# Altium schematic sheet Sens_Prob.SchDoc
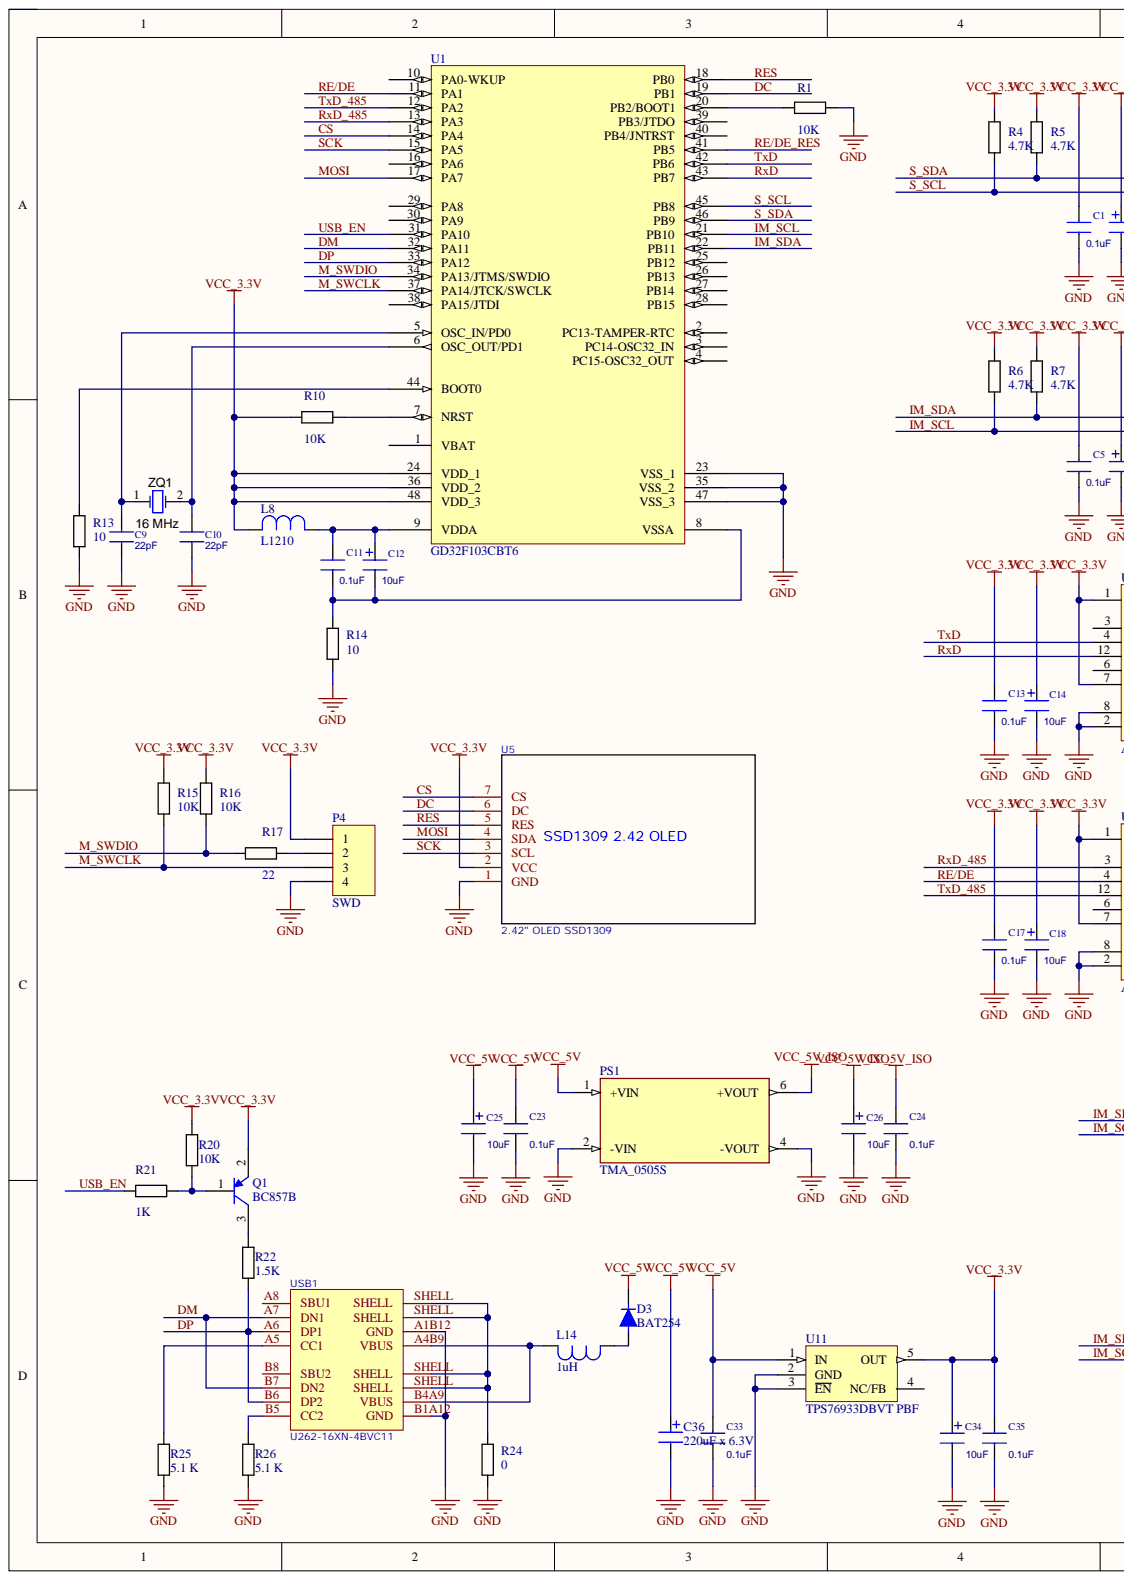
[diagram p0: Sens_Prob.SchDoc, left]
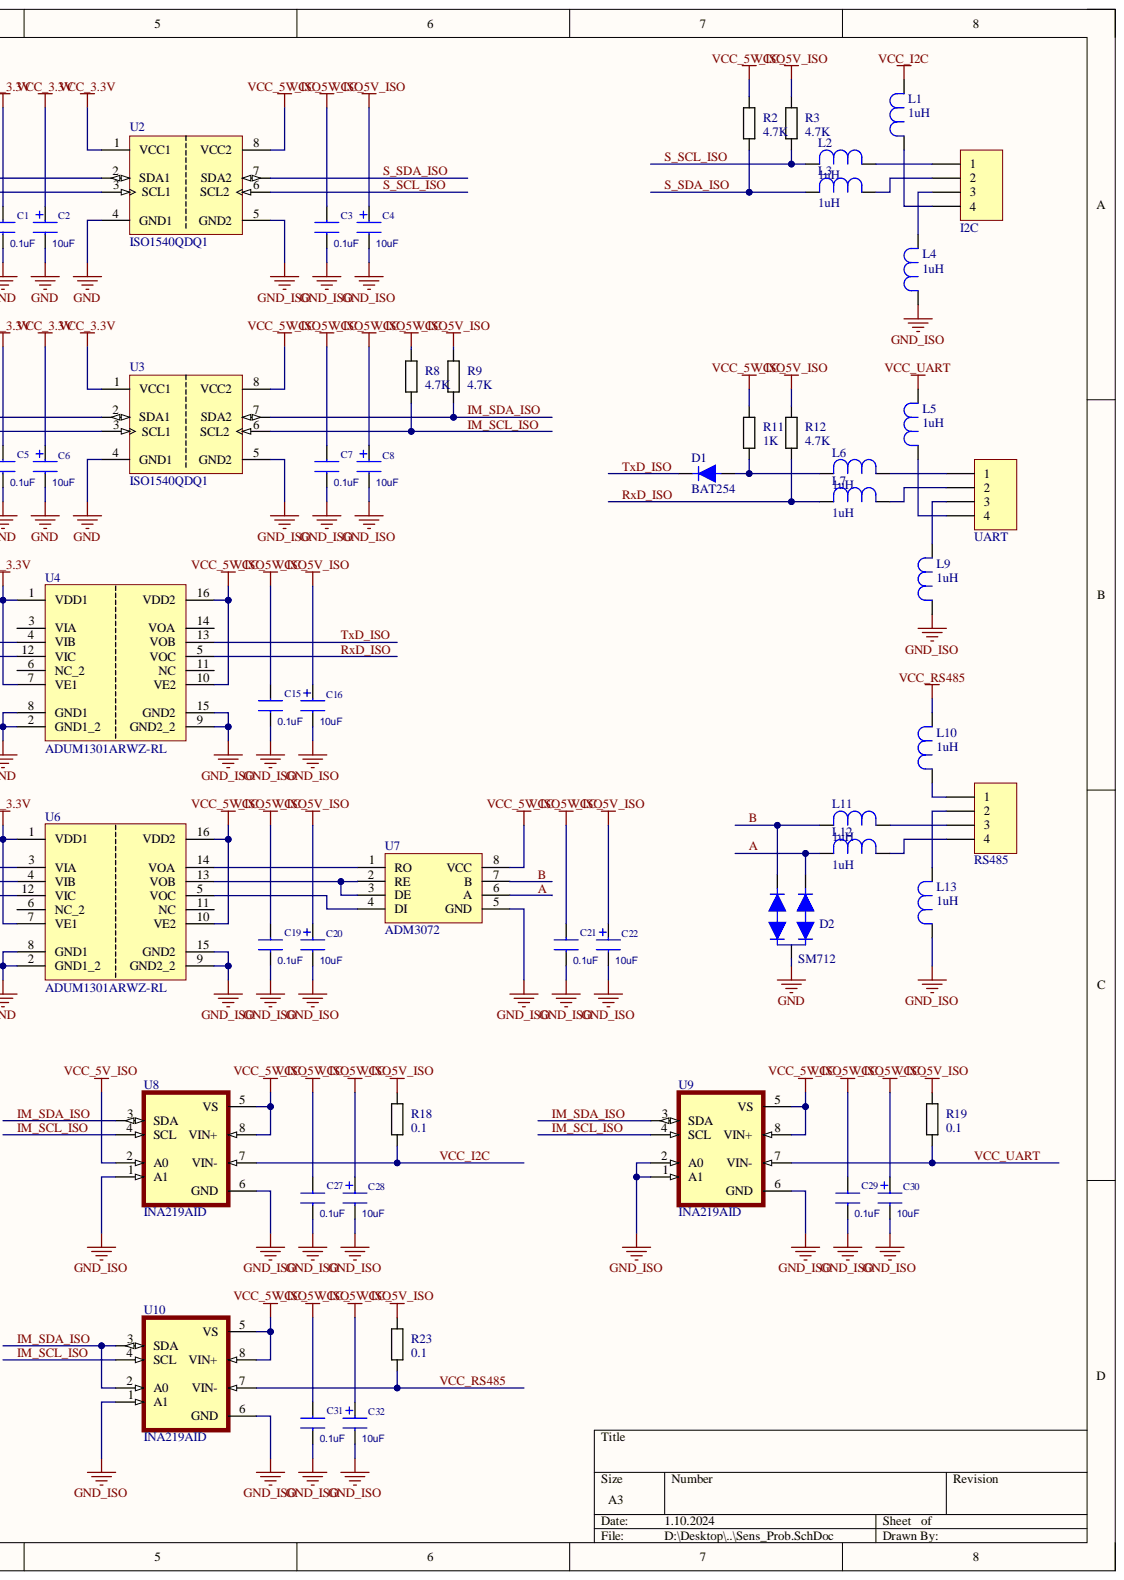
[diagram p1: Sens_Prob.SchDoc, right]

## Parts
C1  0.1uF  [C_0805]
C2  10uF  [CPM3528]
C3  0.1uF  [C_0805]
C4  10uF  [CPM3528]
C5  0.1uF  [C_0805]
C6  10uF  [CPM3528]
C7  0.1uF  [C_0805]
C8  10uF  [CPM3528]
C9  22pF  [C_0805]
C10  22pF  [C_0805]
C11  0.1uF  [C_0805]
C12  10uF  [CPM3528]
C13  0.1uF  [C_0805]
C14  10uF  [CPM3528]
C15  0.1uF  [C_0805]
C16  10uF  [CPM3528]
C17  0.1uF  [C_0805]
C18  10uF  [CPM3528]
C19  0.1uF  [C_0805]
C20  10uF  [CPM3528]
C21  0.1uF  [C_0805]
C22  10uF  [CPM3528]
C23  0.1uF  [C_0805]
C24  0.1uF  [C_0805]
C25  10uF  [CPM3528]
C26  10uF  [CPM3528]
C27  0.1uF  [C_0805]
C28  10uF  [CPM3528]
C29  0.1uF  [C_0805]
C30  10uF  [CPM3528]
C31  0.1uF  [C_0805]
C32  10uF  [CPM3528]
C33  0.1uF  [C_0805]
C34  10uF  [CPM3528]
C35  0.1uF  [C_0805]
C36  220uF x 6.3V  [Radial 8x11.5]
D1  BAT254  [SOD-110]
D2  SM712  [SOT23]
D3  BAT254  [SOD-110]
L1  MLZ2012M100WT000  [L_0805]  (value not drawn)
L2  MLZ2012M100WT000  [L_0805]  (value not drawn)
L3  MLZ2012M100WT000  [L_0805]  (value not drawn)
L4  MLZ2012M100WT000  [L_0805]  (value not drawn)
L5  MLZ2012M100WT000  [L_0805]  (value not drawn)
L6  MLZ2012M100WT000  [L_0805]  (value not drawn)
L7  MLZ2012M100WT000  [L_0805]  (value not drawn)
L8  L1210  [L_1210]
L9  MLZ2012M100WT000  [L_0805]  (value not drawn)
L10  MLZ2012M100WT000  [L_0805]  (value not drawn)
L11  MLZ2012M100WT000  [L_0805]  (value not drawn)
L12  MLZ2012M100WT000  [L_0805]  (value not drawn)
L13  MLZ2012M100WT000  [L_0805]  (value not drawn)
L14  MLZ2012M100WT000  [L_0805]  (value not drawn)
P1  I2C  [MHDR1X4]
P2  UART  [MHDR1X4]
P3  RS485  [MHDR1X4]
P4  SWD  [HDR1X4]
PS1  TMA_0505S  [CONV_TMA_0505S]
Q1  BC857B  [SOT-23A_M]
R1  10K  [Resistor 0805]
R2  4.7K  [Resistor 0805]
R3  4.7K  [Resistor 0805]
R4  4.7K  [Resistor 0805]
R5  4.7K  [Resistor 0805]
R6  4.7K  [Resistor 0805]
R7  4.7K  [Resistor 0805]
R8  4.7K  [Resistor 0805]
R9  4.7K  [Resistor 0805]
R10  10K  [Resistor 0805]
R11  1K  [Resistor 0805]
R12  4.7K  [Resistor 0805]
R13  10  [Resistor 0805]
R14  10  [Resistor 0805]
R15  10K  [Resistor 0805]
R16  10K  [Resistor 0805]
R17  22  [Resistor 0805]
R18  0.1  [RESC6331X70N]
R19  0.1  [RESC6331X70N]
R20  10K  [Resistor 0805]
R21  1K  [Resistor 0805]
R22  1.5K  [Resistor 0805]
R23  0.1  [RESC6331X70N]
R24  0  [Resistor 0805]
R25  5.1 K  [Resistor 0805]
R26  5.1 K  [Resistor 0805]
U1  GD32F103CBT6  [LQFP48_L]
U2  ISO1540QDQ1  [SO-8]
U3  ISO1540QDQ1  [SO-8]
U4  ADUM1301ARWZ-RL  [SOIC16W]
U5  2.42" OLED SSD1309  [2.42" OLED]
U6  ADUM1301ARWZ-RL  [SOIC16W]
U7  ADM3072  [SO-8]
U8  INA219AID  [SO-8]
U9  INA219AID  [SO-8]
U10  INA219AID  [SO-8]
U11  TPS76933DBVT PBF  [sot23-5]
USB1  U262-16XN-4BVC11  [USB-3.1-SMD_U262-161N-4BVC11]
ZQ1  16 MHz  [HC49S]

## Nets
GND: C1.1 C2.2 C5.1 C6.2 C9.1 C10.1 C13.1 C14.2 C17.1 C18.2 C23.1 C24.1 C25.2 C26.2 C33.1 C34.2 C35.1 C36.2 D2.3 P4.4 PS1.2(-VIN) PS1.4(-VOUT) R1.2 R13.1 R14.1 R24.1 R25.1 R26.1 U1.23(VSS_1) U1.35(VSS_2) U1.47(VSS_3) U2.4(GND1) U3.4(GND1) U4.2(GND1_2) U4.8(GND1) U5.1(GND) U6.2(GND1_2) U6.8(GND1) U11.2(GND) U11.3(EN) USB1.A1B12(GND) USB1.B1A12(GND)
GND_ISO: C3.1 C4.2 C7.1 C8.2 C15.1 C16.2 C19.1 C20.2 C21.1 C22.2 C27.1 C28.2 C29.1 C30.2 C31.1 C32.2 L4.2 L9.2 L13.2 U2.5(GND2) U3.5(GND2) U4.9(GND2_2) U4.15(GND2) U6.9(GND2_2) U6.15(GND2) U7.5(GND) U8.1(A1) U8.6(GND) U9.1(A1) U9.2(A0) U9.6(GND) U10.1(A1) U10.6(GND)
VCC_3.3V: C1.2 C2.1 C5.2 C6.1 C13.2 C14.1 C17.2 C18.1 C34.1 C35.2 L8.1 P4.1 Q1.2 R4.2 R5.2 R6.2 R7.2 R10.2 R15.2 R16.2 R20.2 U1.24(VDD_1) U1.36(VDD_2) U1.48(VDD_3) U2.1(VCC1) U3.1(VCC1) U4.1(VDD1) U4.7(VE1) U5.2(VCC) U6.1(VDD1) U6.7(VE1) U11.5(OUT)
VCC_5V: C23.2 C25.1 C33.2 C36.1 D3.2 PS1.1(+VIN) U11.1(IN)
VCC_5V_ISO: C3.2 C4.1 C7.2 C8.1 C15.2 C16.1 C19.2 C20.1 C21.2 C22.1 C24.2 C26.1 C27.2 C28.1 C29.2 C30.1 C31.2 C32.1 PS1.6(+VOUT) R2.2 R3.2 R8.2 R9.2 R11.2 R12.2 R18.2 R19.2 R23.2 U2.8(VCC2) U3.8(VCC2) U4.10(VE2) U4.16(VDD2) U6.10(VE2) U6.16(VDD2) U7.8(VCC) U8.2(A0) U8.5(VS) U8.8(VIN+) U9.5(VS) U9.8(VIN+) U10.5(VS) U10.8(VIN+)
A: D2.1 L12.2
B: D2.2 L11.2 U7.7(B)
CS: U1.14(PA4) U5.7(CS)
DC: U1.19(PB1) U5.6(DC)
DM: U1.32(PA11) USB1.A7(DN1) USB1.B7(DN2)
DP: R22.1 U1.33(PA12) USB1.A6(DP1) USB1.B6(DP2)
IM_SCL: R6.1 U1.21(PB10) U3.3(SCL1)
IM_SCL_ISO: R8.1 U3.6(SCL2) U8.4(SCL) U9.4(SCL) U10.4(SCL)
IM_SDA: R7.1 U1.22(PB11) U3.2(SDA1)
IM_SDA_ISO: R9.1 U3.7(SDA2) U8.3(SDA) U9.3(SDA) U10.2(A0) U10.3(SDA)
M_SWCLK: P4.3 R15.1 U1.37(PA14/JTCK/SWCLK)
M_SWDIO: R16.1 R17.1 U1.34(PA13/JTMS/SWDIO)
MOSI: U1.17(PA7) U5.4(SDA)
RE/DE: U1.11(PA1) U6.4(VIB)
RE/DE_RES: U1.41(PB5)
RES: U1.18(PB0) U5.5(RES)
RxD: U1.43(PB7) U4.12(VIC)
RxD_485: U1.13(PA3) U6.3(VIA)
RxD_ISO: L7.2 R12.1 U4.5(VOC)
S_SCL: R4.1 U1.45(PB8) U2.3(SCL1)
S_SCL_ISO: L2.2 R3.1 U2.6(SCL2)
S_SDA: R5.1 U1.46(PB9) U2.2(SDA1)
S_SDA_ISO: L3.2 R2.1 U2.7(SDA2)
SCK: U1.15(PA5) U5.3(SCL)
TxD: U1.42(PB6) U4.4(VIB)
TxD_485: U1.12(PA2) U6.12(VIC)
TxD_ISO: D1.2 U4.13(VOB)
USB_EN: R21.2 U1.31(PA10)
VCC_I2C: L1.1 R18.1 U8.7(VIN-)
VCC_RS485: L10.1 R23.1 U10.7(VIN-)
VCC_UART: L5.1 R19.1 U9.7(VIN-)
NetC9_2: C9.2 U1.5(OSC_IN/PD0) ZQ1.1
NetC10_2: C10.2 U1.6(OSC_OUT/PD1) ZQ1.2
NetC11_1: C11.1 C12.2 R14.2 U1.8(VSSA)
NetC11_2: C11.2 C12.1 L8.2 U1.9(VDDA)
NetD1_1: D1.1 L6.2 R11.1
NetD3_1: D3.1 L14.2
NetL1_2: L1.2 P1.4
NetL2_1: L2.1 P1.1
NetL3_1: L3.1 P1.2
NetL4_1: L4.1 P1.3
NetL5_2: L5.2 P2.4
NetL6_1: L6.1 P2.1
NetL7_1: L7.1 P2.2
NetL9_1: L9.1 P2.3
NetL10_2: L10.2 P3.1
NetL11_1: L11.1 P3.3
NetL12_1: L12.1 P3.4
NetL13_1: L13.1 P3.2
NetL14_1: L14.1 USB1.A4B9(VBUS) USB1.B4A9(VBUS)
NetP4_2: P4.2 R17.2
NetQ1_1: Q1.1 R20.1 R21.1
NetQ1_3: Q1.3 R22.2
NetR1_1: R1.1 U1.20(PB2/BOOT1)
NetR10_1: R10.1 U1.7(NRST)
NetR13_2: R13.2 U1.44(BOOT0)
NetR24_2: R24.2 USB1.SHELL
NetR25_2: R25.2 USB1.A5(CC1)
NetR26_2: R26.2 USB1.B5(CC2)
NetU6_5: U6.5(VOC) U7.4(DI)
NetU6_13: U6.13(VOB) U7.2(RE) U7.3(DE)
NetU6_14: U6.14(VOA) U7.1(RO)

Not connected: U1 1(VBAT) 2(PC13-TAMPER-RTC) 3(PC14-OSC32_IN) 4(PC15-OSC32_OUT) 10(PA0-WKUP) 16(PA6) 25(PB12) 26(PB13) 27(PB14) 28(PB15) 29(PA8) 30(PA9) 38(PA15/JTDI) 39(PB3/JTDO) 40(PB4/JNTRST); U4 3(VIA) 6(NC_2) 11(NC) 14(VOA); U6 6(NC_2) 11(NC); U7 6(A); U11 4(NC/FB); USB1 A8(SBU1) B8(SBU2)

## Library data of the parts
C1 C3 C5 C7 C9-C11 C13 C15 C17 C19 C21 C23 C24 C27 C29 C31 C33 C35 = C0805: Capacitor; Component Kind: Standard; Library Name: AX_Altium_v2.3.IntLib
  C1 C3 C5 C7 C11 C13 C15 C17 C19 C21 C23 C24 C27 C29 C31 C33 C35: Value: 0.1uF
  C9 C10: Value: 22pF
C2 C4 C6 C8 C12 C14 C16 C18 C20 C22 C25 C26 C28 C30 C32 C34 = CPM3528: Capacitor; Component Kind: Standard; Library Name: AX_Altium_v2.3.IntLib; Value: 10uF
C36 = Cap Radial: Component Kind: Standard; Library Name: My.IntLib
L1-L14 = L1210: Inductor; Component Kind: Standard; Library Name: AX_Altium_v2.3.IntLib
P1-P3 = MHDR1X4: Header, 4-Pin; Component Kind: Standard; LatestRevisionDate: 17-Jul-2002; LatestRevisionNote: Re-released for DXP Platform.; Library Name: Miscellaneous Connectors.IntLib; Signal Integrity: Connector; Publisher: Altium Limited
P4 = Header 4: Header, 4-Pin; Component Kind: Standard; LatestRevisionDate: 17-Jul-2002; LatestRevisionNote: Re-released for DXP Platform.; Library Name: Miscellaneous Connectors.IntLib; Signal Integrity: Connector; Publisher: Altium Limited
PS1 = TMA_0505S: DC-DC Converter, Single, 5V@200mA, Input, 5VDC@+/-10%, TMA Series | TRACO Power TMA 0505S; Check_prices: https://www.snapeda.com/parts/TMA%200505S/Traco+Power/view-part/?ref=eda; Component Kind: Standard; Library Name: My.IntLib; MF: Traco Power; MP: TMA 0505S; Package: SIP-7 Traco Power; SnapEDA_Link: https://www.snapeda.com/parts/TMA%200505S/Traco+Power/view-part/?ref=snap
Q1 = BC857B: PNP General Purpose Amplifier; Component Kind: Standard; ComponentLink1Description: Manufacturer Link; ComponentLink1URL: http://www.fairchildsemi.com/; ComponentLink2Description: Datasheet; ComponentLink2URL: http://www.fairchildsemi.com/ds/BC%2FBC857.pdf; DatasheetVersion: 1997; LatestRevisionDate: 20-Nov-2009; LatestRevisionNote: IPC-7351 Footprint Added.; Library Name: FSC Discrete BJT.IntLib; PackageDescription: 3-Pin SOT-23 Package 0.95 mm Pitch, 2.3 mm Lead Span; PackageReference: SOT-23A; PackageVersion: Sep-1998; Published: 8-Jun-2000; Signal Integrity: BC857B; Simulation: BC857B; Publisher: Altium Limited
R1-R26 = Resistor: Component Kind: Standard; Library Name: Maks_lib.IntLib
  R1 R10 R15 R16 R20: Value: 10K
  R2-R9 R12: Value: 4.7K
  R11 R21: Value: 1K
  R13 R14: Value: 10
  R17: Value: 22
  R18 R19 R23: Value: 0.1
  R22: Value: 1.5K
  R24: Value: 0
  R25 R26: Value: 5.1 K
U1 = STM32F103CBT6: Component Kind: Standard; ComponentLink1Description: Manufacturer Link; ComponentLink1URL: www.st.com/stm32; ComponentLink2Description: Datasheet; ComponentLink2URL: http://www.st.com/stonline/products/literature/ds/13587.pdf; DatasheetVersion: rev6, Mar-2008; Library Name: ST Microcontroller 32-Bit STM32.IntLib; PackageDescription: 48-Pin Low-Profile Quad Flat Pack, 7 x 7 mm Body, 0.5 mm Pitch (LQFP48); PackageReference: LQFP48; PackageVersion: Mar-2008; Published: 30-May-2008; Publisher: Altium Limited
U4 U6 = ADUM1301ARWZ-RL: General Purpose Digital Isolator 2500Vrms 3 Channel 1Mbps 25kV/Âµs CMTI 16-SOIC _0.295, 7.50mm Width_; MF: Analog Devices; Component Kind: Standard; Digi-Key_Part_Number: ADUM1301ARWZ-RLCT-ND; Digi-Key_Purchase_URL: https://www.digikey.ru/product-detail/en/analog-devices-inc/ADUM1301ARWZ-RL/ADUM1301ARWZ-RLCT-ND/1089620?utm_source=snapeda&utm_medium=aggregator&utm_campaign=symbol; Library Name: My.IntLib; MP: ADUM1301ARWZ-RL; Package: SOIC WIDE-16 Analog Devices; SnapEDA_Link: https://www.snapeda.com/parts/ADUM1301ARWZ-RL/Analog%20Devices%20Inc./view-part/1237701/?ref=snap
U7 = MAX3362AKA: Component Kind: Standard; Library Name: My.IntLib
U8-U10 = INA219AID: 26V, 12-bit, i2c output current/voltage/power monitor 8-SOIC -40 to 125; MF: Texas Instruments; Availability: Warning; Check_prices: https://www.snapeda.com/parts/INA219AID/Texas%20Instruments/view-part/522322/?ref=eda; Component Kind: Standard; Library Name: My.SchLib; MP: INA219AID; Package: SOIC-8 Texas Instruments; Price: None; Purchase-URL: https://pricing.snapeda.com/search/part/INA219AID/?ref=eda; SnapEDA_Link: https://www.snapeda.com/parts/INA219AID/Texas%20Instruments/view-part/522322/?ref=snap
ZQ1 = XTAL: Crystal Oscillator; Component Kind: Standard; LatestRevisionDate: 17-Jul-2002; LatestRevisionNote: Re-released for DXP Platform.; PackageReference: R38; Published: 8-Jun-2000; Library Name: Sergeev_altium_2012.03.10.IntLib; Publisher: Altium Limited
D1 D3 = BAT254: Component Kind: Standard; Library Name: My.IntLib
D2 = SM712: Component Kind: Standard; Library Name: My.IntLib
U2 U3 = ISO1540QDQ1: Availability: Unavailable; Component Kind: Standard; Library Name: My.IntLib; MP: ISO1540QDQ1; Package: None; Price: None
U5 = 2.42" OLED SSD1309: Component Kind: Standard; Library Name: My.IntLib
U11 = TPS76933DBVT PBF: Component Kind: Standard; Library Name: My.IntLib
USB1 = U262-16XN-4BVC11: Component Kind: Standard; Library Name: My.SchLib
